# Altium schematic sheet HA_Power.SchDoc
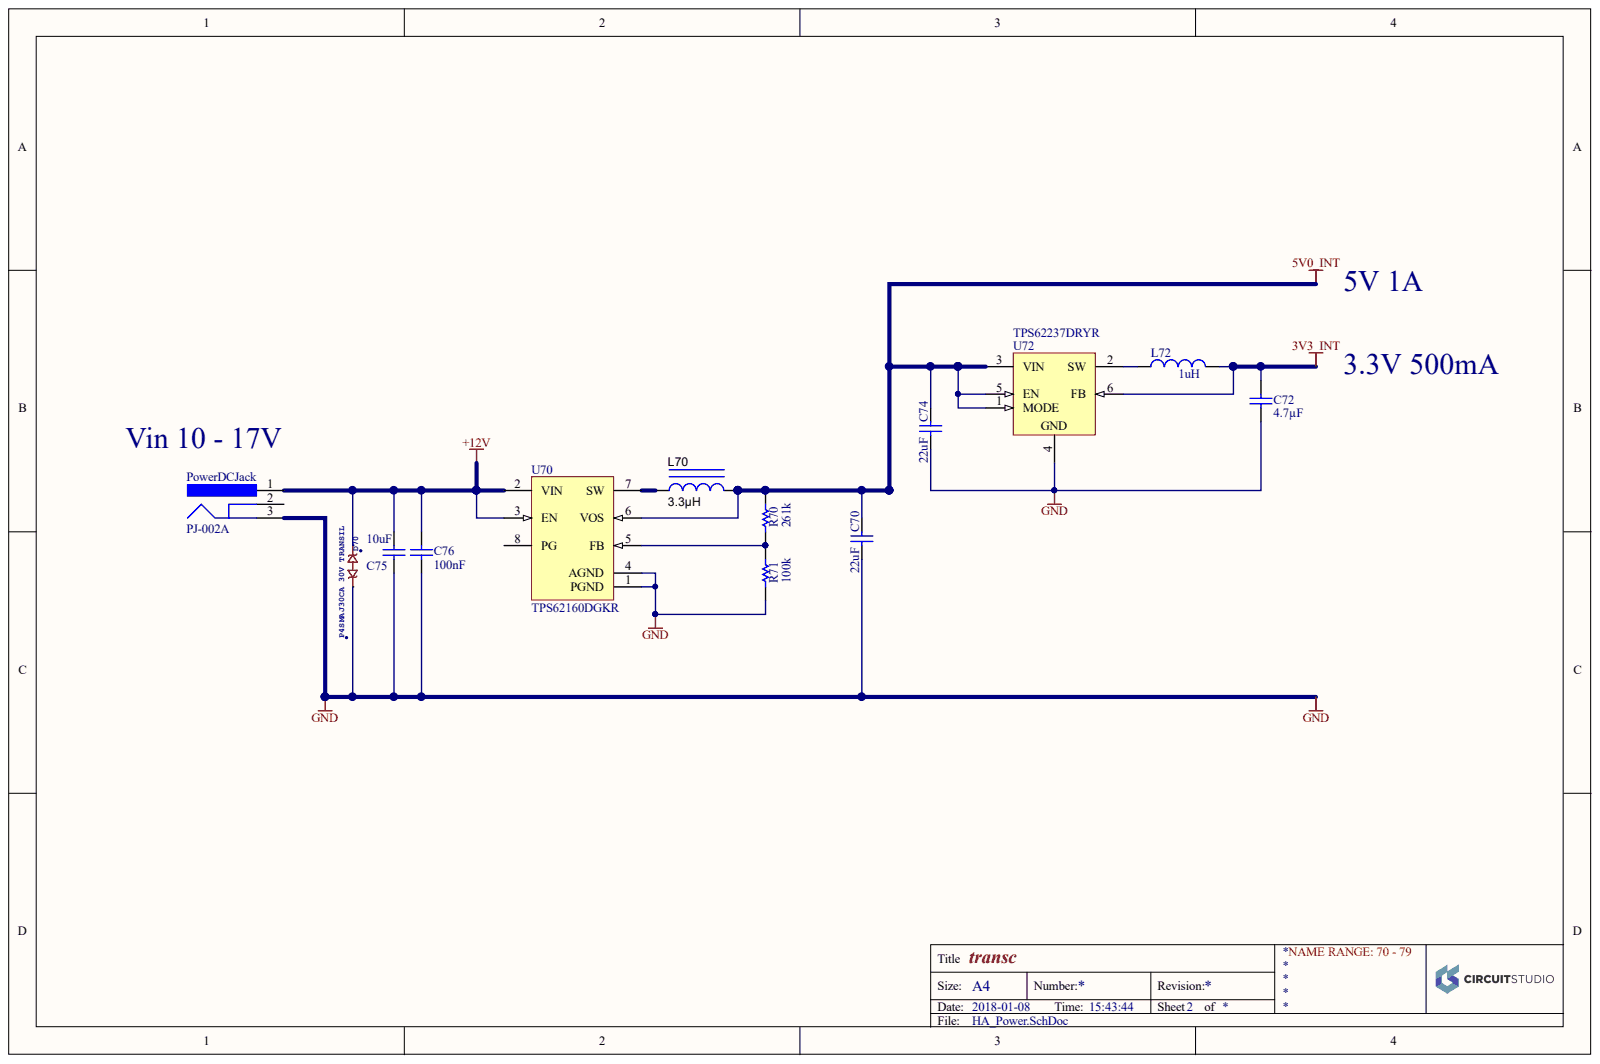
[diagram p0: HA_Power.SchDoc]

## Parts
C70  value 22uF  [CAPC0805(2012)75_N]  (hidden comment: 22uF 10V)
C72  Capacitance: 4.7µF  [CAPC1608X95X30NL15T20]  (hidden comment: CL10B475KQ8NQNC)
C74  value 22uF  [CAPC0805(2012)75_N]  (hidden comment: 22uF 10V)
C75  value 10uF  [CAPC1206(3216)190_N]  (hidden comment: CAP 10uF 35V 1206(3216))
C76  value 100nF  [CAPC0603(1608)100_L]  (hidden comment: CAP 100nF 100V 0603(1608))
D70  P4SMAJ30CA 30V TRANSIL  [DO214AC_BIDIR]
L70  ValueDisplayed: 3.3µH  [XPL2010]  (hidden comment: XPL2010-332MLB)
L72  Inductance: 1uH  [MURA-IND0805_H_0.55_M]  (hidden comment: LQM21PN2R2MC0D)
PowerDCJack  PJ-002A  [CUI-PJ-002A_V]
R70  261k  [RESC0603(1608)_N]
R71  100k  [RESC0603(1608)_N]
U70  TPS62160DGKR  [DGK8_N]
U72  TPS62237DRYR  [DRY0006A]

## Nets
3V3_INT: C72.2 L72.2 U72.6(FB)
5V0_INT: C70.2 C74.2 L70.2 R70.2 U70.6(VOS) U72.1(MODE) U72.3(VIN) U72.5(EN)
+12V: C75.2 C76.1 D70.2 PowerDCJack.1 U70.2(VIN) U70.3(EN)
GND: C70.1 C72.1 C74.1 C75.1 C76.2 D70.1 PowerDCJack.3 R71.1 U70.1(PGND) U70.4(AGND) U72.4
NetL70_1: L70.1 U70.7(SW)
NetL72_1: L72.1 U72.2(SW)
NetR70_1: R70.1 R71.2 U70.5(FB)

Not connected: PowerDCJack 2; U70 8(PG)

## Library data of the parts
C70 C74 = CMP-1036-00417-1: Case-EIA: 0805; Case-Metric: 2012; Tolerance: ±10%; Component Kind: Standard; Library Name: Altium Content Vault; Max Thickness: 0.75 mm; Rated Voltage: 10 V; Technology: SMT
C72 = CMP-2000-06096-1: Chip Capacitor, 4.7 uF, +/- 10%, 6.3 V, -55 to 125 degC, 0603 (1608 Metric), RoHS, Tape and Reel; Manufacturer: Samsung Electro-Mechanics; Featured Product: Multi-LayerCeramicCapacitors; Online Catalog: CLSeries,HighCapacitance; Other Names: 1276-2087-2; Product Training Modules: AutoGradeMLCCHighCapMLCCFamilySoftTerminationMLCC; Temperature Coefficient: X7R; Voltage - Rated: 6.3V; Applications: GeneralPurpose; Category: Capacitors; Component Kind: Standard; Datasheet URL: http://media.digikey.com/pdf/Data%20Sheets/Samsung%20PDFs/CL10B475KQ8NQNC_Spec.pdf; Datasheet Version: 09/2013; Family: CeramicCapacitors; Features: -; Height - Seated (Max): -; Lead Spacing: -; Lead Style: -; Library Name: Altium Content Vault; Manufacturer URL: http://www.samsungsem.com/global/index.jsp; Mounting Technology: SurfaceMount; Mounting Type: SurfaceMount,MLCC; Operating Temperature: -55°C~125°C; Package / Case: 0603(1608Metric); Package Description: 2-Pin Surface Mount Device, Body 1.6 x 0.8 mm; Package Reference: 0603; Packaging: Tape&Reel(TR); Ratings: -; RoHS Information: MLCCRoHS2Compliance; Series: CL; Size / Dimension: 0.063"Lx0.031"W(1.60mmx0.80mm); Standard Package: 4,000; Thickness (Max): 0.035"(0.90mm); Tolerance: ±10%
C75 = CMP-1037-04990-1: CAP 1206 (3216 Metric) Thickness 1.9mm SMD; Case-EIA: 1206; Case-Metric: 3216; Rated Voltage: 10 V; Tolerance: Â±20%; Component Kind: Standard; Library Name: Altium Content Vault; Max Thickness: 1.9 mm; Technology: SMT
C76 = CMP-1035-00529-1: CAP 100nF 100V ±10% 0603 (1608 Metric) Thickness 1mm SMD; Case-EIA: 0603; Case-Metric: 1608; Component Kind: Standard; Library Name: Altium Content Vault; Max Thickness: 1 mm; Rated Voltage: 100 V; Technology: SMT; Tolerance: ±10%
D70 = DIODE_BIDIR-DO214AC: Component Kind: Standard; Library Name: mms.SchLib
L70 = XPL2010-332MLB: XPL2010 Inductor; Manufacturer: Coilcraft; AEC Category: Q200; DCR_ohm: 0.21; Imax_A: 1.05; Ind_H: 3.3E-6; Irms: 1.1A @ 40C rise; Isat: 1.05A @ 30% inductance drop; Material: Composite; SizeArea_mm2: 3.8; SizeAreaWB_mm2: 8.7; SizeX_mm: 2; SizeY_mm: 1.9; SizeZ_mm: 1; Value: 3.3uH; Value1: 0.21 ohm; Value2: 1.05A; AEC Qualified: ?; Component Kind: Standard; ComponentLink1Description: Datasheet link; ComponentLink1URL: http://www.coilcraft.com/pdfs/xpl2010.pdf; CompType: Inductor; LatestRevisionDate: 2016-12-22; Library Name: Inductor_Coilcraft.IntLib; MountType: SMT; PackageReference: XPL2010; PartNumber: XPL2010-332MLB; Published: 2008-06-26; RoHS: Y; Simulation: Inductor; SymbolVersion: 2008-07-23; Technology: Shielded; Publisher: Texas Instruments
L72 = CMP-2000-06589-1: Chip Inductor, 1.0 uH, +/- 20%, -55 to 125 degC, 2-Pin SMD (0805), RoHS, Tape and Reel; Manufacturer: Murata; DC Resistance: 0.19; Rated Current-Max: 800mA; Case Size/Dimension: 0805; Component Kind: Standard; Datasheet URL: https://datasheet.ciiva.com/3866/336lqm21pn1r0mc023-3866307.pdf; Datasheet Version: 07/2014; Library Name: Altium Content Vault; Manufacturer URL: http://www.murata.com/; Mounting Technology: SurfaceMount; Package Description: 2-Pin Surface Mounted Device, Body 2 x 1.25 mm; Package Reference: 0805; Tolerance (%): 20%
PowerDCJack = CMP-2000-06895-1: Through Hole Right Angle DC Power Jack, 2.5 A, 2 mm Center Pin, 3 Position, -25 to 85 degC, RoHS, Bulk; Manufacturer: CUIInc.; Brand: CUI; Current Rating: 2.5A; Inside Contact Diameter: 2mm; Insulation Resistance: 50MOhms; Outside Contact Diameter: 6.5mm; Voltage Rating: 24V; Component Kind: Standard; Connector Type: DCPowerJack; Contact Gender: Male; Contact Material: Copper; Contact Plating: Nickel; Datasheet URL: http://www.cui.com/product/resource/pj-002a.pdf; Datasheet Version: Rev. 1.03, 04/2016; Factory Pack Quantity: 500; Flammability Rating: UL94V-0; Housing Material: PolybutyleneTerephthalate(PBT); Library Name: Altium Content Vault; Manufacturer URL: http://www.cui.com/; Mounting Angle: Right; Mounting Style: ThroughHole; Mounting Technology: ThroughHole; Operating Temperature Range: -25Cto+85C; Package Description: 3-Pin Through Hole Device, Body 14.4 x 9 mm, Height 11 mm; Package Reference: PJ-002A; Product: Jacks; Product Category: DCPowerConnectors; RoHS: True; Termination Style: ThroughHole
R70 R71 = CMP-1012-00122-1: 1% 0603 SMD; Value: 22k Ohm; Component Kind: Standard; Library Name: Altium Content Vault; Tolerance: 1 %
U70 = CMP-0323-00440-1: Buck Step Down Regulator with 3 to 17 V Input and 0.9 to 6 V Output, -40 to 85 degC, 8-Pin VSOP (DGK), Green (RoHS & no Sb/Br); Manufacturer: Texas Instruments; Duty Cycle(Max)(%): 100; Iout(Max)(A): 1; Iq(Typ)(mA): 0.017; Rating: Catalog; SubFamily: Step-Down Regulator; Switch Current Limit(Typ)(A): 1.8; Synchronous Rectification: TRUE; Vin(Max)(V): 17; Vin(Min)(V): 3; Vout(Max)(V): 6; Vout(Min)(V): 0.9; Code_JEDEC: MO-187-AA; Component Kind: Standard; ComponentLink1Description: Datasheet; ComponentLink1URL: http://www.ti.com/general/docs/lit/getliterature.tsp?genericPartNumber=TPS62160&fileType=pdf; ComponentLink2Description: URL Link1; ComponentLink2URL: Samples URL:http://www.ti.com/product/tps62160#samples; DatasheetVersion: SLVSAM2A; Eco-Plan: Green (RoHS & no Sb/Br); Library Name: Altium Content Vault; Mounting Technology: Surface Mount; PackageDescription: 8-Pin Thin Small Outline Package 3 x 3 mm Body ,0.65 mm Pitch; PackageReference: DGK8; PackageVersion: revE, May-2006; PartNumber: TPS62160DGKR; Regulated Outputs(#): 1; Topology: Buck
U72 = CMP-0323-00243-3: Buck Step Down Regulator with 2.05 to 6 V Input and 3.3 V Output, -40 to 85 degC, 6-Pin SON (DRY), Green (RoHS & no Sb/Br); Manufacturer: Texas Instruments; Duty Cycle(Max)(%): 100; Iout(Max)(A): 0.5; Iq(Typ)(mA): 0.022; Preset Vout(V): 3.3; Rating: Catalog; Regulated Outputs(#): 1; SubFamily: Step-Down Regulator; Switch Current Limit(Typ)(A): 0.84; Synchronous Rectification: TRUE; Vin(Max)(V): 6; Vin(Min)(V): 2.05; Component Kind: Standard; ComponentLink1Description: Datasheet; ComponentLink1URL: http://www.ti.com/general/docs/lit/getliterature.tsp?genericPartNumber=TPS62237&fileType=pdf; ComponentLink2Description: Package Specification; ComponentLink2URL: http://www.ti.com/litv/pdf/mpds221e; ComponentLink3Description: URL Link1; ComponentLink3URL: Samples URL:http://www.ti.com/product/tps62237#samples; DatasheetVersion: SLVS941E; Eco-Plan: Green (RoHS & no Sb/Br); Library Name: Altium Content Vault; Mounting Technology: Surface Mount; PackageDescription: 6-Pin Plastic Small Outline No-Lead, 1 x 1.45 x 0.6 mm Body, 0.5 mm Pitch; PackageReference: DRY0006A; PackageVersion: revF, Dec-2011; PartNumber: TPS62237DRYR; Topology: Buck; Vout(Max)(V): 3.3; Vout(Min)(V): 3.3
